# Altium schematic sheet MCU.SchDoc
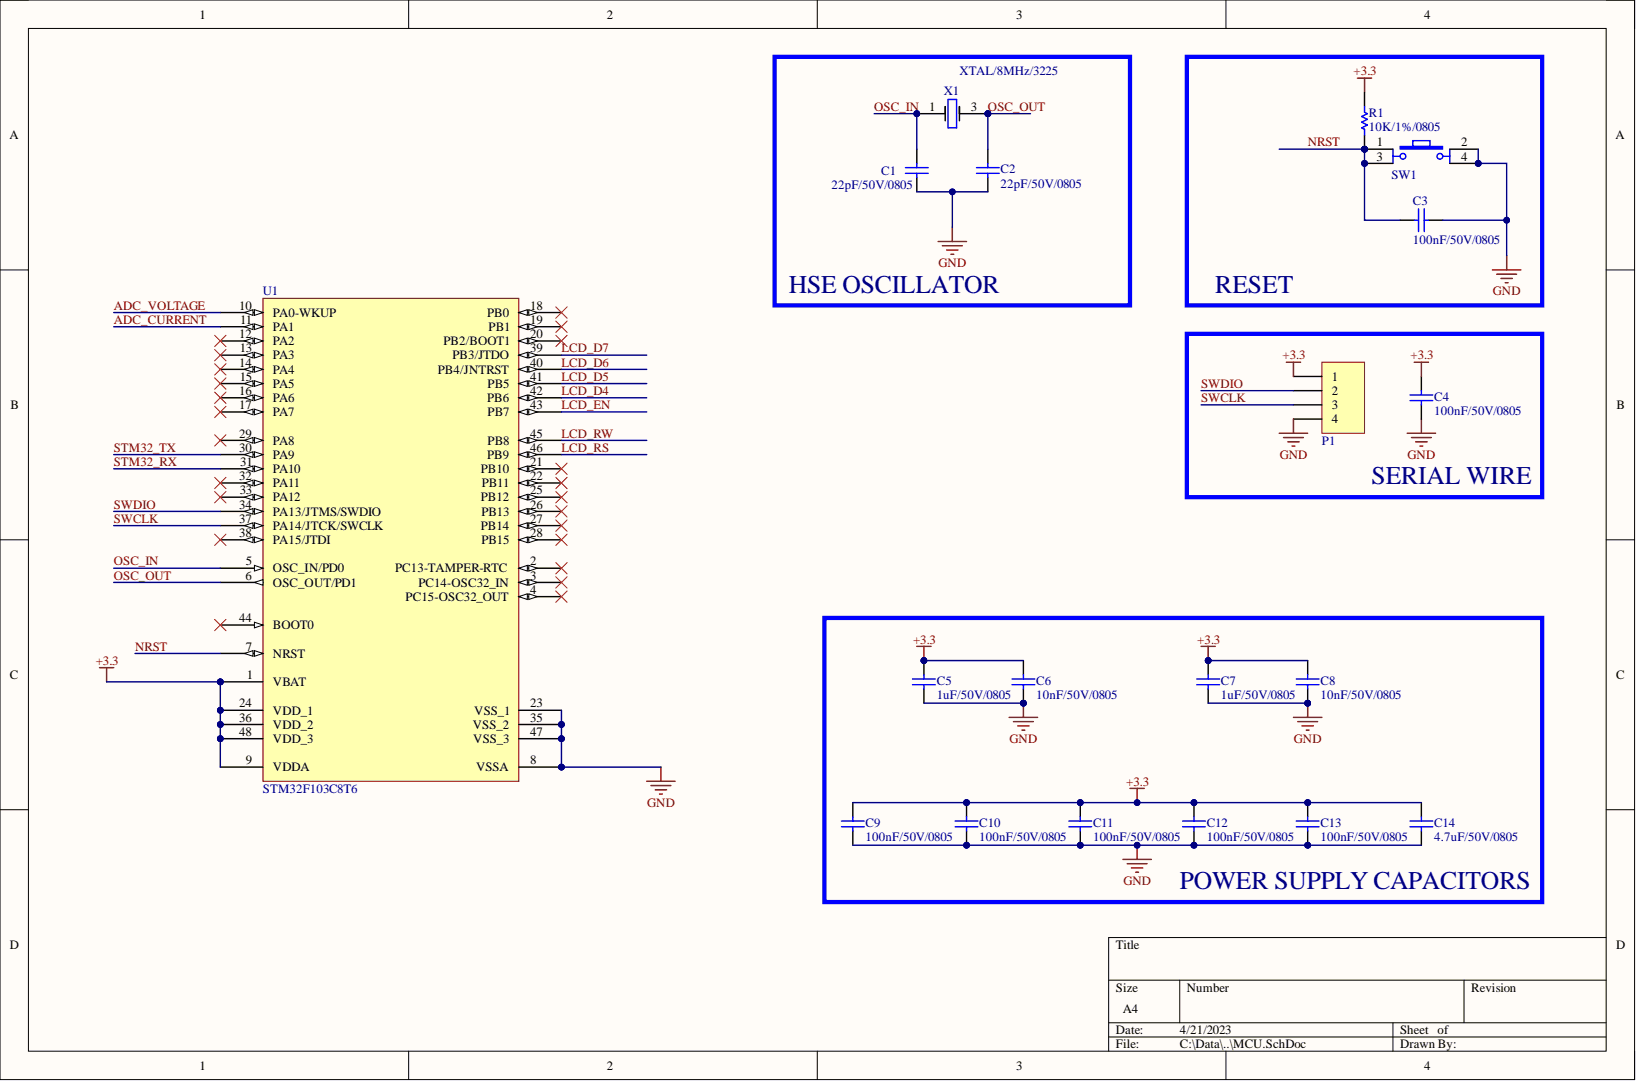
[diagram p0: MCU.SchDoc]

## Parts
C1  value 22pF/50V/0805  [CAP-SM-0805]  (hidden comment: Cap Non-Pol SMD)
C2  value 22pF/50V/0805  [CAP-SM-0805]  (hidden comment: Cap Non-Pol SMD)
C3  value 100nF/50V/0805  [CAP-SM-0805]  (hidden comment: Cap Non-Pol SMD)
C4  value 100nF/50V/0805  [CAP-SM-0805]  (hidden comment: Cap Non-Pol SMD)
C5  value 1uF/50V/0805  [CAP-SM-0805]  (hidden comment: Cap Non-Pol SMD)
C6  value 10nF/50V/0805  [CAP-SM-0805]  (hidden comment: Cap Non-Pol SMD)
C7  value 1uF/50V/0805  [CAP-SM-0805]  (hidden comment: Cap Non-Pol SMD)
C8  value 10nF/50V/0805  [CAP-SM-0805]  (hidden comment: Cap Non-Pol SMD)
C9  value 100nF/50V/0805  [CAP-SM-0805]  (hidden comment: Cap Non-Pol SMD)
C10  value 100nF/50V/0805  [CAP-SM-0805]  (hidden comment: Cap Non-Pol SMD)
C11  value 100nF/50V/0805  [CAP-SM-0805]  (hidden comment: Cap Non-Pol SMD)
C12  value 100nF/50V/0805  [CAP-SM-0805]  (hidden comment: Cap Non-Pol SMD)
C13  value 100nF/50V/0805  [CAP-SM-0805]  (hidden comment: Cap Non-Pol SMD)
C14  value 4.7uF/50V/0805  [CAP-SM-0805]  (hidden comment: Cap Non-Pol SMD)
P1  Header 4  [JP4]  (value not drawn)
R1  value 10K/1%/0805  [RES-SM-0805]  (hidden comment: RESISTOR SMD)
SW1  SW  [Tact SW 4 pin]
U1  STM32F103C8T6  [TSQFP50P900X900X160-48N]
X1  XTAL/8MHz/3225  [XTAL 3225]

## Nets
+3.3: C4.1 C5.1 C6.1 C7.1 C8.1 C9.1 C10.1 C11.1 C12.1 C13.1 C14.1 P1.1 R1.2 U1.1(VBAT) U1.9(VDDA) U1.24(VDD_1) U1.36(VDD_2) U1.48(VDD_3)
GND: C1.1 C2.1 C3.2 C4.2 C5.2 C6.2 C7.2 C8.2 C9.2 C10.2 C11.2 C12.2 C13.2 C14.2 P1.4 SW1.2 SW1.4 U1.8(VSSA) U1.23(VSS_1) U1.35(VSS_2) U1.47(VSS_3)
ADC_CURRENT: U1.11(PA1)
ADC_VOLTAGE: U1.10(PA0-WKUP)
LCD_D4: U1.42(PB6)
LCD_D5: U1.41(PB5)
LCD_D6: U1.40(PB4/JNTRST)
LCD_D7: U1.39(PB3/JTDO)
LCD_EN: U1.43(PB7)
LCD_RS: U1.46(PB9)
LCD_RW: U1.45(PB8)
NRST: C3.1 R1.1 SW1.1 SW1.3 U1.7(NRST)
OSC_IN: C1.2 U1.5(OSC_IN/PD0) X1.1
OSC_OUT: C2.2 U1.6(OSC_OUT/PD1) X1.3
STM32_RX: U1.31(PA10)
STM32_TX: U1.30(PA9)
SWCLK: P1.3 U1.37(PA14/JTCK/SWCLK)
SWDIO: P1.2 U1.34(PA13/JTMS/SWDIO)

Not connected: U1 2(PC13-TAMPER-RTC) 3(PC14-OSC32_IN) 4(PC15-OSC32_OUT) 12(PA2) 13(PA3) 14(PA4) 15(PA5) 16(PA6) 17(PA7) 18(PB0) 19(PB1) 20(PB2/BOOT1) 21(PB10) 22(PB11) 25(PB12) 26(PB13) 27(PB14) 28(PB15) 29(PA8) 32(PA11) 33(PA12) 38(PA15/JTDI) 44(BOOT0)

## Library data of the parts
C1-C14 = Cap Non-Pol SMD: Capacitor; Component Kind: Standard; Library Name: PIF_Library.IntLib
P1 = Header 4: Header, 4-Pin; Component Kind: Standard; LatestRevisionDate: 17-Jul-2002; LatestRevisionNote: Re-released for DXP Platform.; Library Name: PIF_Library.IntLib; Publisher: Altium Limited
R1 = RESISTOR SMD: Resistor; Component Kind: Standard; Library Name: PIF_Library.IntLib
SW1 = Tact SW - 4Pin: Switch button 4 pin; Value: Button chip 4 pin; Component Kind: Standard; Library Name: PIF_Library.IntLib
U1 = STM32F103C8T6: Manufacturer: ST; Component Kind: Standard; ComponentLink1Description: Supplier 1 Link; Library Name: MiclsLib.IntLib
X1 = XTAL: Value: 16MHz; Component Kind: Standard; Library Name: MiclsLib.IntLib
